# Altium schematic sheet 2wire.SchDoc
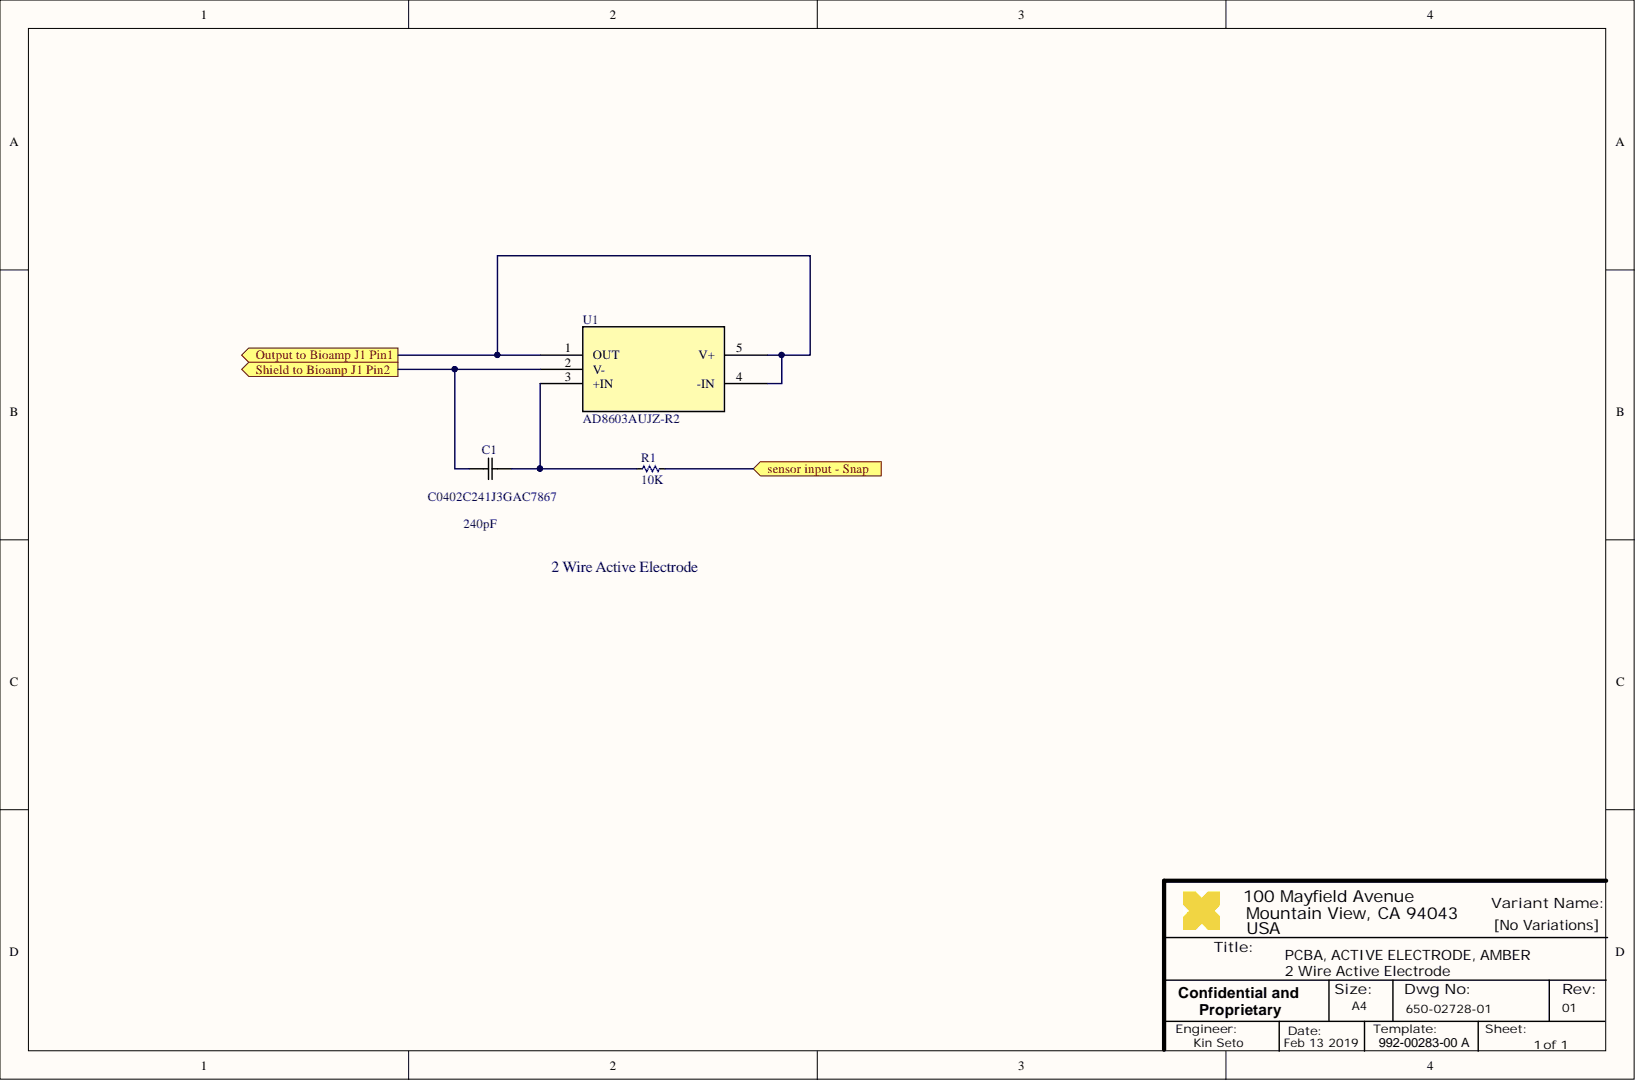
[diagram p0: 2wire.SchDoc]

## Parts
C1  C0402C241J3GAC7867  value 240pF  [G-155]
R1  value 10K  [R0402]
U1  AD8603AUJZ-R2  [UJ_5]

## Ports
Output to Bioamp J1 Pin1, sensor input - Snap, Shield to Bioamp J1 Pin2

## Nets
Output to Bioamp J1 Pin1: U1.1(OUT) U1.4(-IN) U1.5(V+) ; port Output to Bioamp J1 Pin1
sensor input - Snap: R1.2 ; port sensor input - Snap
Shield to Bioamp J1 Pin2: C1.1 U1.2(V-) ; port Shield to Bioamp J1 Pin2
NetC1_2: C1.2 R1.1 U1.3(+IN)

## Library data of the parts
C1 = C0402C241J3GAC7867 - 240pf: Imported; Vendor: KEMET; Component Kind: Standard; Library Name: C0402C241J3GAC7867.IntLib; Manufacturer_Part_Number: C0402C241J3GAC7867
R1 = 8355: RES, 10K, 0.1%, 1/16W, 0402; COMMON: 470-02401-00; Database Table Name: Resistors; MFG: PANASONIC; MFG P/N: ERA-2AEB103X; PROXY: Use ID #8534; Component Kind: Standard; Gecko: 470-02401-00; ID: 8355; Library Name: AltiumReleaseLibDB.DBLib; LOON: 470-02401-00; Package: 0402; Power: 1/16W; Status: Released; Tolerance: 0.1%; XPN: 470-02401-00
U1 = AD8603AUJZ-R2: No Description Available; Built_By: Analog_Devices_Team; Vendor: Analog Devices Inc; Component Kind: Standard; Library Name: AD8603AUJZ.IntLib; Manufacturer_Part_Number: AD8603AUJZ-R2; RefDes: RefDes; Type: DEV; Value: Value; Copyright: Copyright (C) 2018 Accelerated Designs. All rights reserved
